# Altium schematic sheet sensors.SchDoc(sensors)
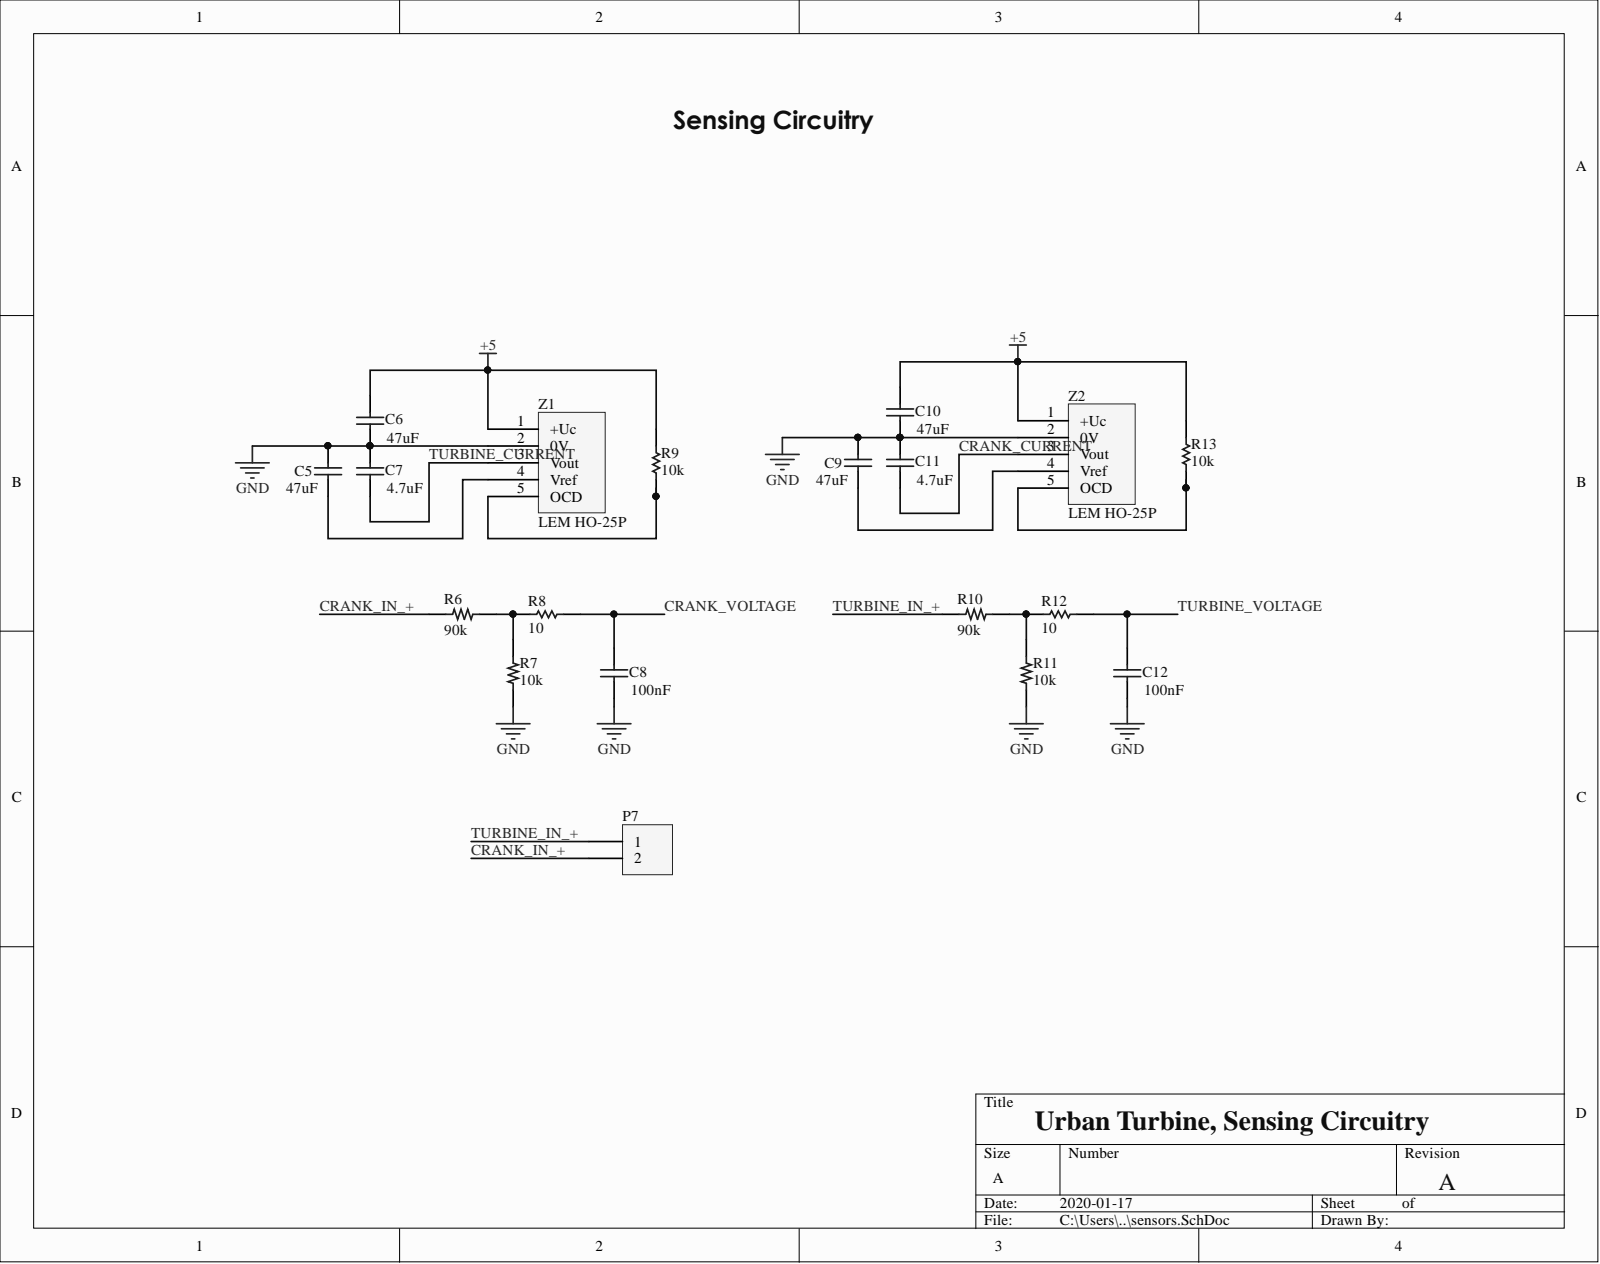
[diagram p0: sensors.SchDoc]

## Parts
C5  value 47uF  [0402]  (hidden comment: Cap Semi)
C6  value 47uF  [0402]  (hidden comment: Cap Semi)
C7  value 4.7uF  [0402]  (hidden comment: Cap Semi)
C8  value 100nF  [0402]  (hidden comment: Cap Semi)
C9  value 47uF  [0402]  (hidden comment: Cap Semi)
C10  value 47uF  [0402]  (hidden comment: Cap Semi)
C11  value 4.7uF  [0402]  (hidden comment: Cap Semi)
C12  value 100nF  [0402]  (hidden comment: Cap Semi)
P7  (no value)  [HDR1X2]
R6  90k  [6-0805_M]
R7  10k  [6-0805_M]
R8  value 10  [J1-0603]  (hidden comment: ERJ-3EKF1002V)
R9  value 10k  [6-0805_M]  (hidden comment: ERJ-3EKF1002V)
R10  90k  [6-0805_M]
R11  10k  [6-0805_M]
R12  value 10  [J1-0603]  (hidden comment: ERJ-3EKF1002V)
R13  value 10k  [6-0805_M]  (hidden comment: ERJ-3EKF1002V)
Z1  LEM HO-25P  [HO-25]
Z2  LEM HO-25P  [HO-25]

## Nets
+5: C6.1 C10.1 R9.2 R13.2 Z1.1(+Uc) Z2.1(+Uc)
GND: C5.1 C6.2 C7.1 C8.2 C9.1 C10.2 C11.1 C12.2 R7.1 R11.1 Z1.2(0V) Z2.2(0V)
CRANK_CURRENT: C11.2 Z2.3(Vout)
CRANK_IN_+: P7.2 R6.2
CRANK_VOLTAGE: C8.1 R8.1
TURBINE_CURRENT: C7.2 Z1.3(Vout)
TURBINE_IN_+: P7.1 R10.2
TURBINE_VOLTAGE: C12.1 R12.1
NetC5_2: C5.2 Z1.4(Vref)
NetC9_2: C9.2 Z2.4(Vref)
NetR6_1: R6.1 R7.2 R8.2
NetR9_1: R9.1 Z1.5(OCD)
NetR10_1: R10.1 R11.2 R12.2
NetR13_1: R13.1 Z2.5(OCD)

## Library data of the parts
C5-C12 = Cap Semi: Capacitor (Semiconductor SIM Model); Simulation: CAP; Signal Integrity: Cap Semi; Published: 8-Jun-2000; PackageReference: C1206; PackageDescription: Chip Capacitor; Library Name: Miscellaneous Devices.IntLib; LatestRevisionNote: IPC-7351 Footprint Added.; LatestRevisionDate: 29-May-2009; Component Kind: Standard; Publisher: Altium Limited
P7 = Header 2: Header, 2-Pin; Signal Integrity: Connector; Library Name: Miscellaneous Connectors.IntLib; LatestRevisionNote: Re-released for DXP Platform.; LatestRevisionDate: 17-Jul-2002; Component Kind: Standard; Publisher: Altium Limited
R6 R10 = 10k-125mW-0805-1%: Resistor, 10k, 125mW Power Rating, 0805 Package; ManufacturerReference: MCR10EZPF1002; Value: 10k Ohm; PowerRating: 125mWatt; Other: 1%; InventoryNumber: RES038; PackageReference: 0805; DistributorReference: RHM10.0KCRCT-ND; DistributorName: Digikey; Component Kind: Standard; Category: Resistor
  R6: Library Name: Miscellaneous Devices.IntLib
  R10: Library Name: Resistor SMD.SchLib
R7 R11 = 22k-125mW-0805-1%: Resistor, 22k, 125mW Power Rating, 0805 Package; ManufacturerReference: RMCF0805FT22K0; Value: 22k Ohm; PowerRating: 125mWatt; Other: 1%; InventoryNumber: RES044; PackageReference: 0805; Library Name: Resistor SMD.SchLib; DistributorReference: RMCF0805FT22K0CT-ND; DistributorName: Digikey; Component Kind: Standard; Category: Resistor
R8 R9 R12 R13 = ERJ-3EKF1002V: RES SMD 10K OHM 1% 1/10W 0603; Manufacturer: Panasonic Electronic Components; Temperature Coefficient: ±100ppm/°C; Power (Watts): 0.1W, 1/10W; Tolerance: ±1%; Supplier Part Number 1: P10.0KHCT-ND; Supplier Device Package: 0603; Supplier 1: Digi-Key; Stock 1: 5981773; Size / Dimension: 0.063" L x 0.031" W (1.60mm x 0.80mm); RoHS: RoHS Compliant; Resistance (Ohms): 10k; Pricing 1: 1=0.15, 50=0.017, 100=0.0125, 250=0.0096, 500=0.00766, 1000=0.00564 (CAD); Packaging: Cut Tape (CT); Package / Case: 0603 (1608 Metric); Operating Temperature: -55°C ~ 155°C; Number of Terminations: 2; Manufacturer Part Number: ERJ-3EKF1002V; Height: 0.022" (0.55mm); Features: Automotive AEC-Q200; Composition: Thick Film; ComponentLink1URL: http://industrial.panasonic.com/www-cgi/jvcr13pz.cgi?E+PZ+3+AOA0002+ERJ3EKF1002V+7+WW; ComponentLink1Description: http://industrial.panasonic.com/www-cgi/jvcr13pz.cgi?E+PZ+3+AOA0002+ERJ3EKF1002V+7+WW; Component Kind: Standard; Category: Resistors
Z1 Z2 = LEM HO-25P: Hall Effect Current Sensor; Component Kind: Standard; Library Name: urbanturbine.SchLib
